# Altium schematic sheet CurrentTransPCB.SchDoc(CurrentTransPCB)
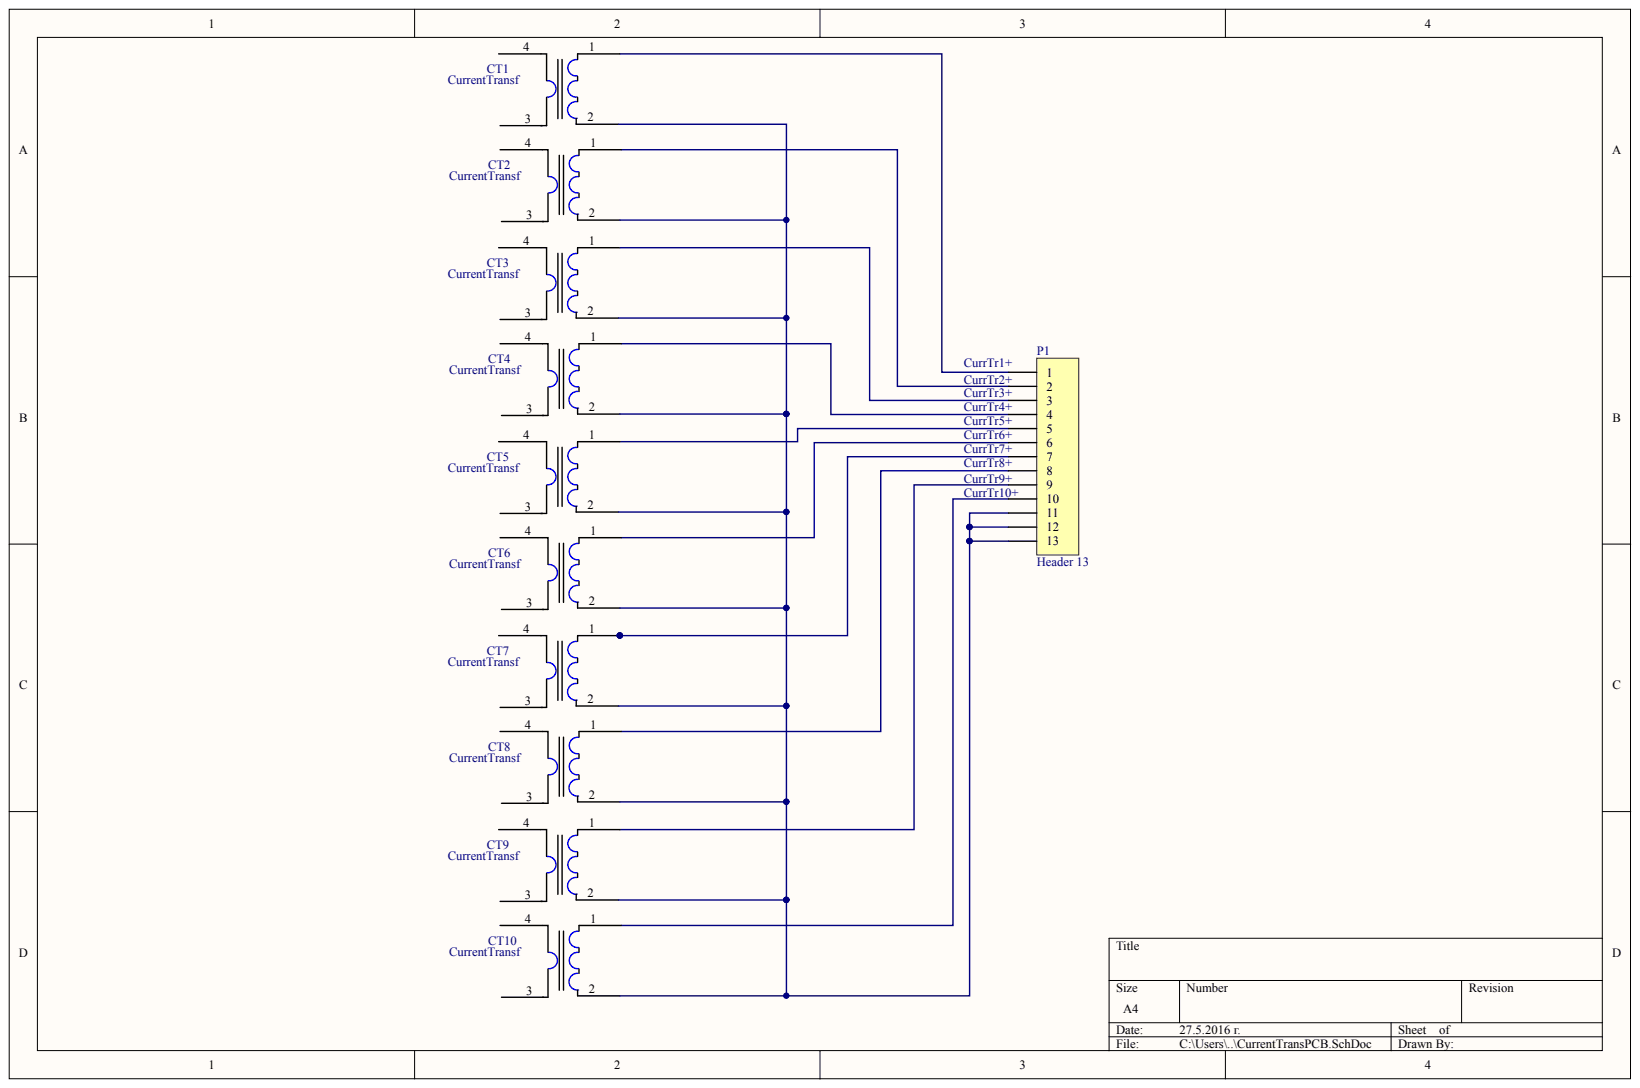
[diagram p0: CurrentTransPCB.SchDoc]

## Parts
CT1  CurrentTransf
CT2  CurrentTransf
CT3  CurrentTransf
CT4  CurrentTransf
CT5  CurrentTransf
CT6  CurrentTransf
CT7  CurrentTransf
CT8  CurrentTransf
CT9  CurrentTransf
CT10  CurrentTransf
P1  Header 13

## Nets
NetCT1_1: CT1.1 P1.1
NetCT1_2: CT1.2 CT2.2 CT3.2 CT4.2 CT5.2 CT6.2 CT7.2 CT8.2 CT9.2 CT10.2 P1.11 P1.12 P1.13
NetCT2_1: CT2.1 P1.2
NetCT3_1: CT3.1 P1.3
NetCT4_1: CT4.1 P1.4
NetCT5_1: CT5.1 P1.5
NetCT6_1: CT6.1 P1.6
NetCT7_1: CT7.1 P1.7
NetCT8_1: CT8.1 P1.8
NetCT9_1: CT9.1 P1.9
NetCT10_1: CT10.1 P1.10

Not connected: CT1 3 4; CT2 3 4; CT3 3 4; CT4 3 4; CT5 3 4; CT6 3 4; CT7 3 4; CT8 3 4; CT9 3 4; CT10 3 4

## Library data of the parts
CT7 = (no symbol name): Manufacturer: NanJing ZeMing Electronics Co.Ltd; Suppl.PN#: ZMCT116A; Supplier: NanJing ZeMing Electronics Co.Ltd
CT1-CT6 CT8-CT10 P1 = (no symbol name)
  P1: LatestRevisionDate: 17-Jul-2002; LatestRevisionNote: Re-released for DXP Platform.; Publisher: Altium Limited
